# Altium schematic sheet 1. Power&Connectors.SchDoc("1. Power&Connectors")
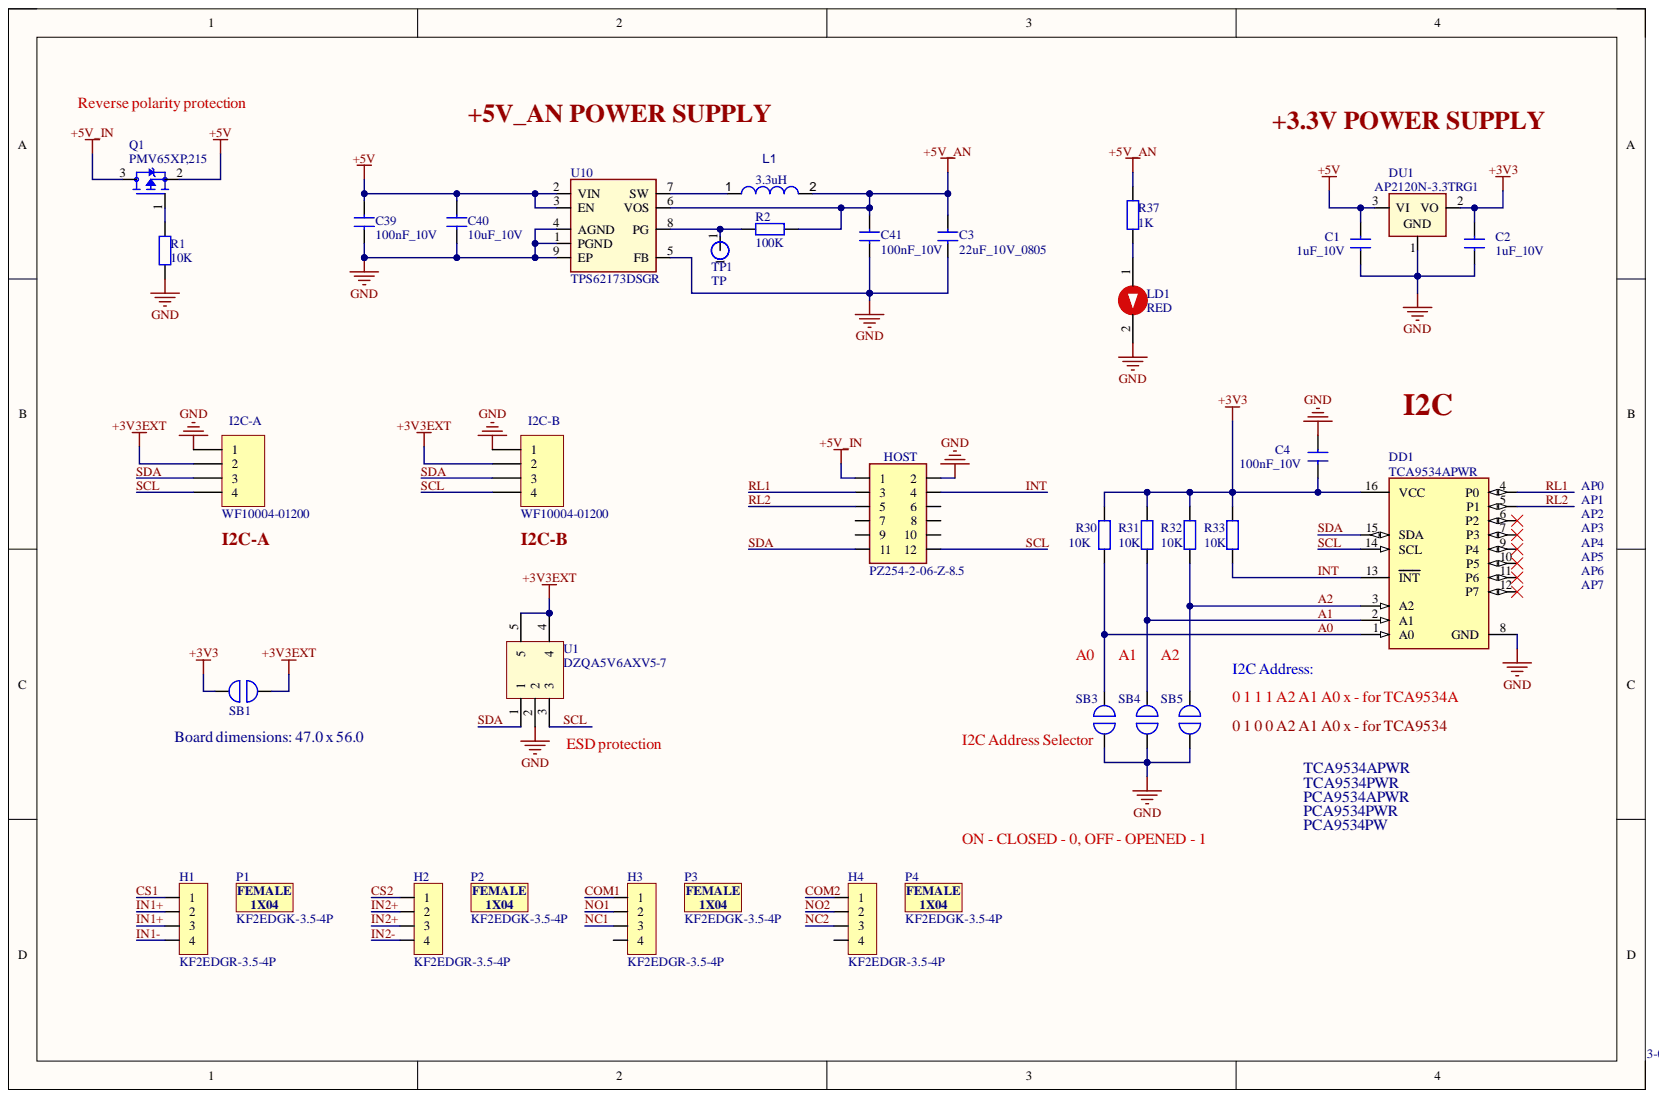
[diagram p0: 1. Power&Connectors.SchDoc]

## Parts
C1  1uF_10V  [C0603]
C2  1uF_10V  [C0603]
C3  22uF_10V_0805  [C0805]
C4  100nF_10V  [CN-SMD-0402]
C39  100nF_10V  [CN-SMD-0402]
C40  10uF_10V  [CN-SMD-0402]
C41  100nF_10V  [CN-SMD-0402]
DD1  TCA9534APWR  [PW0016A TSSOP16]
DU1  AP2120N-3.3TRG1  [SOT95P240X110-3N]
H1  KF2EDGR-3.5-4P  [CON_1X04_3.5MM_MALE_RA]
H2  KF2EDGR-3.5-4P  [CON_1X04_3.5MM_MALE_RA]
H3  KF2EDGR-3.5-4P  [CON_1X04_3.5MM_MALE_RA]
H4  KF2EDGR-3.5-4P  [CON_1X04_3.5MM_MALE_RA]
HOST  PZ254-2-06-Z-8.5  [PLD-12]
I2C-A  WF10004-01200  [SM04B-SRSS-TB(LF)(SN)]
I2C-B  WF10004-01200  [SM04B-SRSS-TB(LF)(SN)]
L1  3.3uH  [L0805]
LD1  RED  [LED 0603]
P1  KF2EDGK-3.5-4P  (mechanical)
P2  KF2EDGK-3.5-4P  (mechanical)
P3  KF2EDGK-3.5-4P  (mechanical)
P4  KF2EDGK-3.5-4P  (mechanical)
Q1  PMV65XP,215  [SOT95P230X110-3N]
R1  10K  [RESC-0603 (1608) - HD]
R2  100K  [RESC-0603 (1608) - HD]
R30  10K  [RESC-0603 (1608) - HD]
R31  10K  [RESC-0603 (1608) - HD]
R32  10K  [RESC-0603 (1608) - HD]
R33  10K  [RESC-0603 (1608) - HD]
R37  1K  [RESC-0603 (1608) - HD]
SB1  SOLDER_JUMPER_NO  [SOLDER_JUMPER_NO]  (value not drawn)
SB3  SOLDER_JUMPER_NO  [SOLDER_JUMPER_NO]  (value not drawn)
SB4  SOLDER_JUMPER_NO  [SOLDER_JUMPER_NO]  (value not drawn)
SB5  SOLDER_JUMPER_NO  [SOLDER_JUMPER_NO]  (value not drawn)
TP1  TP  [TP0.75]  (no BOM)
U1  DZQA5V6AXV5-7  [SOT50P160X60-5N]
U10  TPS62173DSGR  [WSON-8]

## Nets
+3V3: C2.2 C4.1 DD1.16 DU1.2 R30.1 R31.1 R32.1 R33.1 SB1.1
+3V3EXT: I2C-A.2 I2C-B.2 SB1.2 U1.4 U1.5
+5V: C1.2 C39.2 C40.2 DU1.3 Q1.2 U10.2 U10.3
+5V_AN: C3.2 C41.2 L1.2 R2.2 R37.2 U10.6
+5V_IN: HOST.1 Q1.3
GND: C1.1 C2.1 C3.1 C4.2 C39.1 C40.1 C41.1 DD1.8 DU1.1 HOST.2 I2C-A.1 I2C-B.1 LD1.2 R1.1 SB3.1 SB4.1 SB5.1 U1.2 U10.1 U10.4 U10.5 U10.9
A0: DD1.1 R30.2 SB3.2
A1: DD1.2 R31.2 SB4.2
A2: DD1.3 R32.2 SB5.2
COM1: H3.1
COM2: H4.1
CS1: H1.1
CS2: H2.1
IN1+: H1.2 H1.3
IN1-: H1.4
IN2+: H2.2 H2.3
IN2-: H2.4
INT: DD1.13 HOST.4 R33.2
NC1: H3.3
NC2: H4.3
NO1: H3.2
NO2: H4.2
RL1: DD1.4 HOST.3
RL2: DD1.5 HOST.5
SCL: DD1.14 HOST.12 I2C-A.4 I2C-B.4 U1.3
SDA: DD1.15 HOST.11 I2C-A.3 I2C-B.3 U1.1
NetL1_1: L1.1 U10.7
NetLD1_1: LD1.1 R37.1
NetQ1_1: Q1.1 R1.2
NetR2_1: R2.1 TP1.1 U10.8

Not connected: DD1 6 7 9 10 11 12; H3 4; H4 4; HOST 6 7 8 9 10

## Library data of the parts
C1 C2 = CL05A105KP5NNNC: 10V 1uF X5R ±10% 0603 Multilayer Ceramic Capacitors MLCC - SMD/SMT ROHS; Component Kind: Standard; LCSC #: C14445; Library Name: Bokra.SCHLIB
C3 = CL21A226MPQNNNE: 10V 22uF X5R ±20% 0805 Multilayer Ceramic Capacitors MLCC - SMD/SMT ROHS; Component Kind: Standard; LCSC #: C29277; Library Name: Bokra.SCHLIB
C4 C39 C41 = CL05B104KP5NNNC: 10V 100nF X7R ±10% 0402 Multilayer Ceramic Capacitors MLCC - SMD/SMT ROHS; Component Kind: Standard; LCSC #: C133086; Library Name: Bokra.SCHLIB
C40 = CL05A106MP5NUNC: 10V 10uF X5R ±20% 0402 Multilayer Ceramic Capacitors MLCC - SMD/SMT ROHS; Component Kind: Standard; LCSC #: C315248; Library Name: Bokra.SCHLIB
DD1 = TCA9534APWR: IC I/O EXPANDER I2C 8B 16TSSOP; Manufacturer: Texas Instruments; Frequency: 400kHz; Interface: I2C,SMBus; Max Junction Temp: 100°C; Max Supply Voltage: 5.5V; Min Supply Voltage: 1.65V; Nominal Supply Current: 5-22uA; Number Of I/Os: 8; Standoff Height: 0.05mm; Ambient Temperature Range High: +85°C; Ambient Temperature Range Low: -40°C; Automotive: No; Category: IC; Component Kind: Standard; ComponentLink2Description: Datasheet; ComponentLink2URL: http://www.ti.com/lit/ds/symlink/tca9534a.pdf; Device Class L1: Integrated Circuits (ICs); Device Class L2: Interface ICs; Device Class L3: I/O Expanders; Digikey Description: IC I/O EXPANDER I2C 8B 16TSSOP; Height: 1.2mm; Lead Free: Yes; Manufacturer Part Number: TCA9534APWR; Octopart: https://octopart.com/tca9534apwr-texas+instruments-50333529; Package: PW0016A; RoHS: TRUE; Temperature: -40°C to +85°C; Temperature Range High: +85°C; Temperature Range Low: -40°C; Library Name: Bokra.SCHLIB
DU1 = AP2120N-3.3TRG1: Low-drop voltage regulator 3.3V 0.3A SOT-23; Component Kind: Standard; LCSC #: C156330; Value: AP2120N-3.3TRG1; Library Name: Bokra.SCHLIB
H1-H4 = CON_1X04_3.5MM_MALE_RA: 300V 8A 4 Bend -40℃~+105℃ 1 3.5mm Green Board Edge/Receptacle-Close Push-Pull,P=3.5mm Pluggable System Terminal Block ROHS; Manufacturer: Cixi Kefa Elec; Component Kind: Standard; LCSC #: C441173; Manufacturer Part Number: KF2EDGR-3.5-4P; Supplier 1: LCSC; Library Name: Bokra.SCHLIB
HOST = PLD-12: 2.54mm Header V/T-type, 2x06 Pin; Component Kind: Standard; LCSC #: C2894967; Value: PZ254-2-06-Z-8.5; Library Name: Bokra.SCHLIB
I2C-A I2C-B = Header 4: 1x4P 1 1mm Male pin 4 -25℃~+85℃ 500mA Surface Mount SMD,P=1mm Wire To Board / Wire To Wire Connector ROHS; Manufacturer: SHENZHEN ATOM TECH; Component Kind: Standard; LCSC #: C479990; Manufacturer Part Number: WF10004-01200; Supplier 1: LCSC; Library Name: Bokra.SCHLIB
L1 = BRC2012T3R3MD: 221mΩ 3.3uH 800mA 800mA ±20% 0805 Power Inductors ROHS; Component Kind: Standard; LCSC #: C223147; Value: 3.3uH; Library Name: Bokra.SCHLIB
LD1 = LED 0603 RED: Solder: 0603; Category: LED Diode; Component Kind: Standard; Description: LED 0603 RED; LCSC #: C965799; MPN: LED 0603 RED; Value: RED; Library Name: Bokra.SCHLIB
P1-P4 = CON_1X04_3.5MM_FEMALE_RA: 250V 7A 4 1.5 1 16~28 3.5mm Green Plug P=3.5mm Pluggable System Terminal Block ROHS; Manufacturer: Cixi Kefa Elec; LCSC #: C440849; Manufacturer Part Number: KF2EDGK-3.5-4P; Supplier 1: LCSC; Library Name: Bokra.SCHLIB
Q1 = PMV65XP,215: MOSFET P-CH 20V 2.8A TO236AB; Manufacturer: Nexperia USA; Component Kind: Standard; LCSC #: C75561; Manufacturer Part Number: PMV65XP,215; Supplier 1: LCSC; Library Name: Bokra.SCHLIB
R1 R30-R33 = RC0603FR-0710KL: RES 10K OHM 1% 1/10W 0603; MFR: YAGEO; Solder: SMT; Component Kind: Standard; LCSC #: C98220; MPN: RC0603FR-0710KL; Value: 10K; Library Name: Bokra.SCHLIB
R2 = RC0603FR-07100KL: 100mW Thick Film Resistor 75V ±100ppm/℃ ±1% 100kΩ 0603 Chip Resistor - Surface Mount ROHS; Component Kind: Standard; LCSC #: C14675; MPN: RC0603FR-07100KL; Value: 100K; Library Name: Bokra.SCHLIB
R37 = RC0603FR-071KL: RES SMD 1 KOHM 1% 1/10W 0603; Solder: SMT; Component Kind: Standard; LCSC #: C22548; MPN: RC0603FR-071KL; Value: 1K; Library Name: Bokra.SCHLIB
SB1 SB3-SB5 = SOLDER_JUMPER_NO: Solder Jumper; Component Kind: Net Tie; Library Name: Bokra.SCHLIB
TP1 = TP: TESTPOINT; Library Name: Bokra.SCHLIB
U1 = NZQA5V6XV5T1G: 5.3V 13V 4 3V SOT-553 ESD Protection Devices ROHS; Manufacturer: Diodes Incorporated; Component Kind: Standard; LCSC #: C1973056; Manufacturer Part Number: DZQA5V6AXV5-7; Supplier 1: LCSC; Library Name: Bokra.SCHLIB
U10 = TPS62173DSGR: -40℃~+125℃@(TJ) 1 17uA 2.25MHz 3V~17V 500mA 5V Buck Buck Built-in Fixed Yes WSON-8-EP(2x2) DC-DC Converters ROHS; Component Kind: Standard; LCSC #: C181384; Value: TPS62173DSGR; Library Name: Bokra.SCHLIB
